# Altium schematic sheet ed3.SchDoc
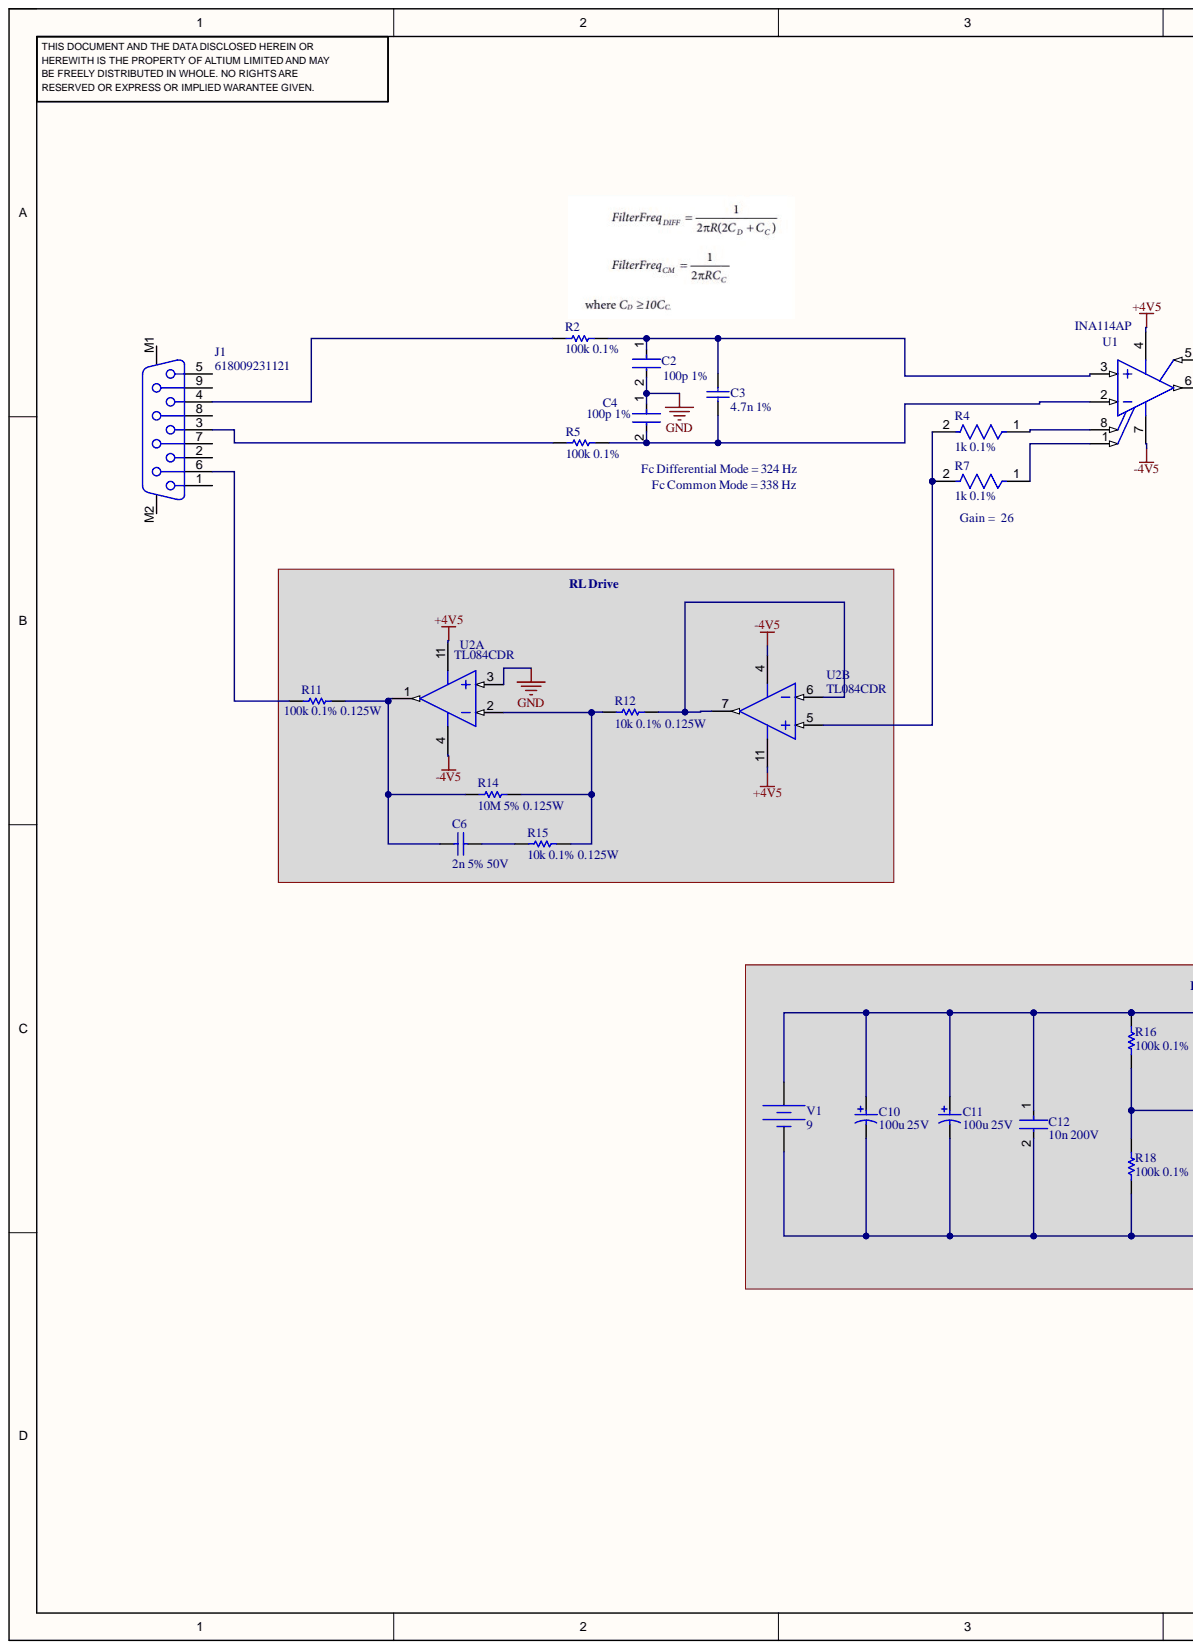
[diagram p0: ed3.SchDoc, left]
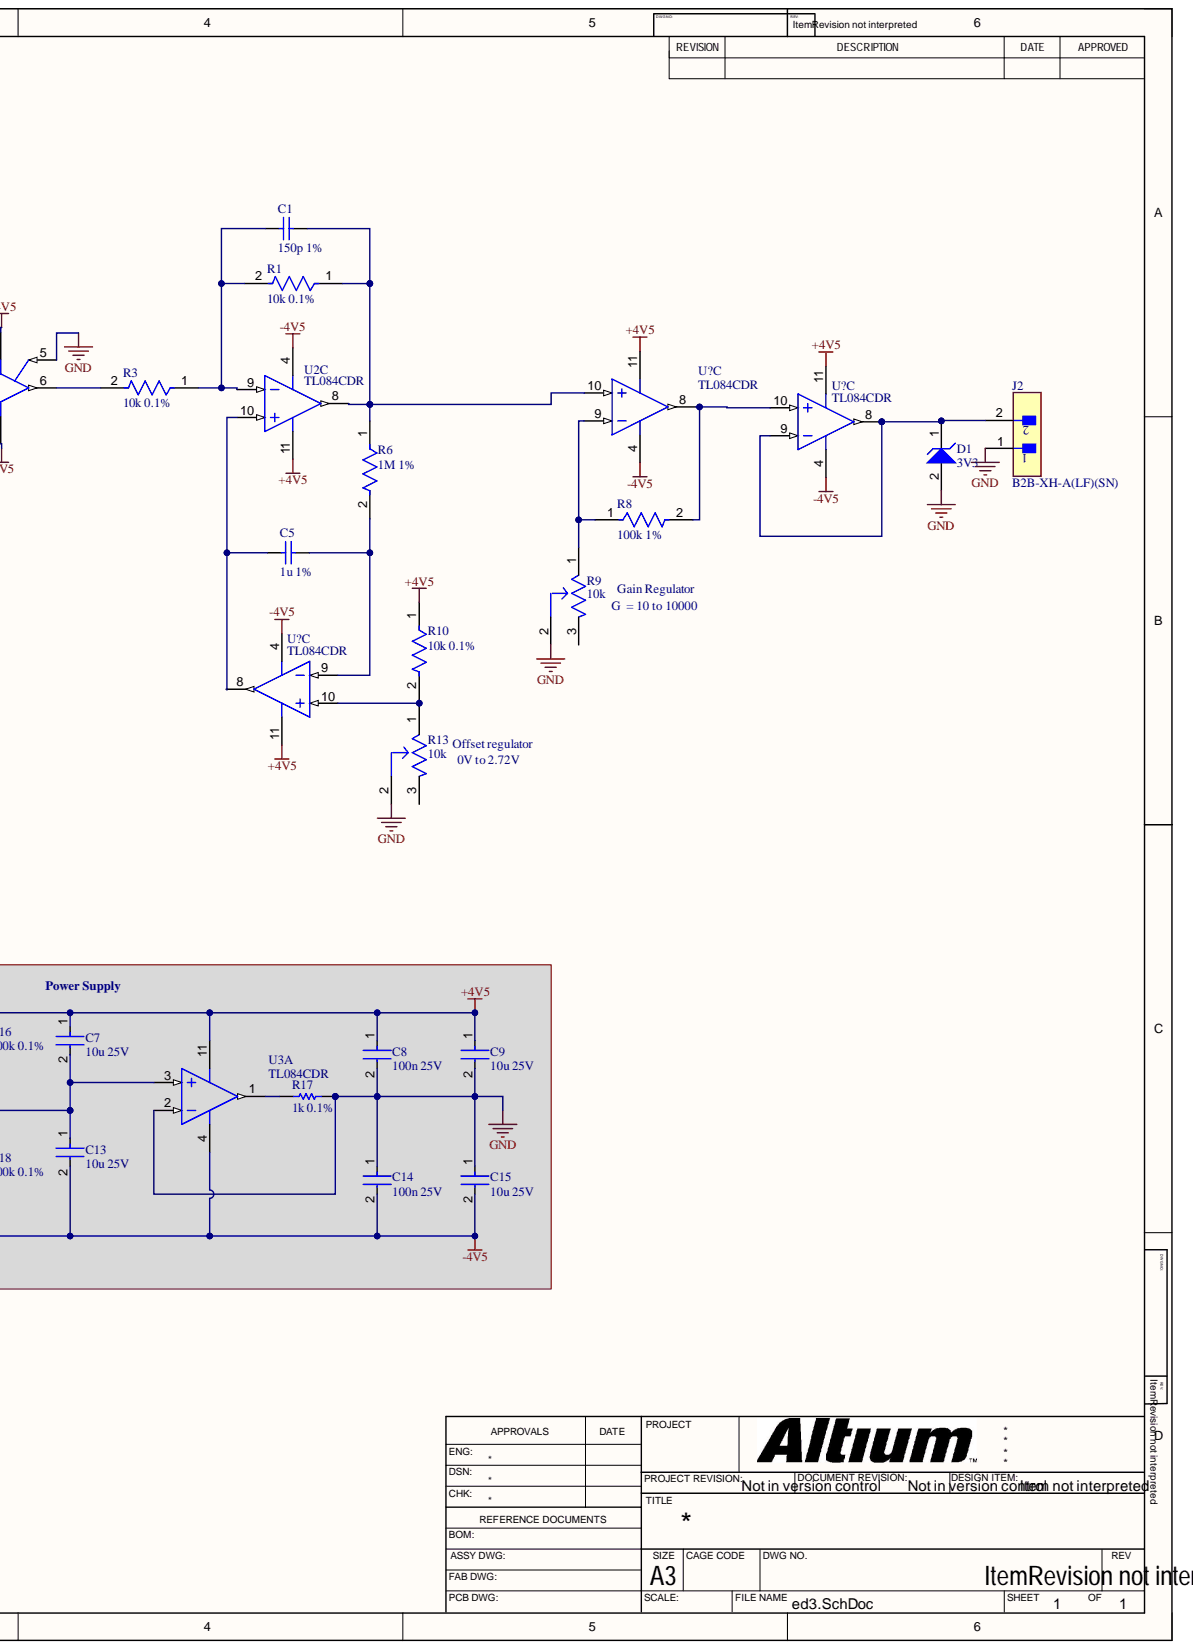
[diagram p1: ed3.SchDoc, right]

## Parts
C1  150p 1%  [CAPC2013X94X50ML20T25]
C2  100p 1%  [FP-0805-L_2_01_0_2-W_1_25-IPC_B]
C3  4.7n 1%  [CAPC2013X94X50ML20T25]
C4  100p 1%  Tolerance: 1%  [FP-0805-L_2_01_0_2-W_1_25-IPC_B]
C5  1u 1%  [CAPC2013X94X50ML20T25]
C6  2n 5% 50V  [CAPC2013X70X45NL10T25]
C7  10u 25V  Voltage: 25V  [FP-GRM21B-0_15-IPC_C]
C8  100n 25V  [FP-C0805C-DN-MFG]
C9  10u 25V  Voltage: 25V  [FP-GRM21B-0_15-IPC_C]
C10  100u 25V  [PNSC-FK-V-D8_V]
C11  100u 25V  Rated Voltage: 25V  [PNSC-FK-V-D8_V]
C12  10n 200V  Voltage: 200V  [FP-0805-L_2_01_0_2-W_1_25-IPC_A]
C13  10u 25V  Voltage: 25V  [FP-GRM21B-0_15-IPC_C]
C14  100n 25V  [FP-C0805C-DN-MFG]
C15  10u 25V  Voltage: 25V  [FP-GRM21B-0_15-IPC_C]
D1  3V3  [ONSC-SOD-123-2-425-04_V]
J1  618009231121  [618009231121]
J2  B2B-XH-A(LF)(SN)  [FP-B2B-XH-A_LF_SN-MFG]
R1  10k 0.1%  Resistance Tolerance: 0.1%  [FP-ERA6A-IPC_C]
R2  100k 0.1%  Tolerance: 0.1%  [RESC2013X60X40LL20T25_A]
R3  10k 0.1%  Resistance Tolerance: 0.1%  [FP-ERA6A-IPC_C]
R4  1k 0.1%  Resistance Tolerance: 0.1%  [FP-ERA6A-IPC_C]
R5  100k 0.1%  Tolerance: 0.1%  [RESC2013X60X40LL20T25_A]
R6  1M 1%  [FP-ERA6A-IPC_C]
R7  1k 0.1%  Resistance Tolerance: 0.1%  [FP-ERA6A-IPC_C]
R8  100k 1%  [FP-ERA6A-IPC_C]
R9  10k  [FP-3266W-MFG]
R10  10k 0.1%  Resistance Tolerance: 0.1%  [FP-ERA6A-IPC_C]
R11  100k 0.1% 0.125W  Tolerance: 0.1%  [RESC2013X60X40LL20T25_A]
R12  10k 0.1% 0.125W  Tolerance: 0.1%  [RESC2013X60X40LL20T25_A]
R13  10k  [FP-3266W-MFG]
R14  10M 5% 0.125W  [RESC2013X50X30LL20T20]
R15  10k 0.1% 0.125W  Tolerance: 0.1%  [RESC2013X60X40LL20T25_A]
R16  100k 0.1%  Tolerance: 0.1%  [RESC2013X60X40LL20T25_A]
R17  1k 0.1%  Tolerance: 0.1%  [RESC2013X60X40LL20T25_A]
R18  100k 0.1%  Tolerance: 0.1%  [RESC2013X60X40LL20T25_A]
U1  INA114AP  [P0008A]
U2  TL084CDR  [D0014A_N]  (parts A,B,C)
U3  TL084CDR  [D0014A_N]  (part A)
U?  TL084CDR  [D0014A_N]  (part C)
U?  TL084CDR  [D0014A_N]  (part C)
U?  TL084CDR  [D0014A_N]  (part C)
V1  Constant Voltage Source  (value not drawn)

## Nets
+4V5: C7.1 C8.1 C9.1 C10.1 C11.1 C12.1 R10.1 R16.2 U1.4 U2.11 U3.11 U?.11 V1.1
-4V5: C10.2 C11.2 C12.2 C13.2 C14.2 C15.2 R18.1 U1.7 U2.4 U3.4 U?.4 V1.2
GND: C2.2 C4.1 C8.2 C9.2 C14.1 C15.1 D1.2 J2.1 R9.2 R13.2 R17.1 U1.5 U2.3 U3.2
NetC1_1: C1.1 R1.1 R6.1 U2.8 U?.10
NetC1_2: C1.2 R1.2 R3.1 U2.9
NetC2_1: C2.1 C3.2 R2.1 U1.3
NetC3_1: C3.1 C4.2 R5.1 U1.2
NetC5_1: C5.1 R6.2 U?.9
NetC5_2: C5.2 U2.10
NetC6_1: C6.1 R11.1 R14.1 U2.1
NetC6_2: C6.2 R15.2
NetC7_2: C7.2 C13.1 R16.1 R18.2 U3.3
NetD1_1: D1.1 J2.2 U?.8 U?.9
NetJ1_3: J1.3 R5.2
NetJ1_4: J1.4 R2.2
NetJ1_6: J1.6 R11.2
NetR3_2: R3.2 U1.6
NetR4_1: R4.1 U1.8
NetR4_2: R4.2 R7.2 U2.5
NetR7_1: R7.1 U1.1
NetR8_1: R8.1 R9.1 U?.9
NetR8_2: R8.2 U?.8 U?.10
NetR10_2: R10.2 R13.1 U?.10
NetR12_1: R12.1 U2.6 U2.7
NetR12_2: R12.2 R14.2 R15.1 U2.2
NetR17_2: R17.2 U3.1

Not connected: J1 1 2 5 7 8 9 M1 M2; R9 3; R13 3

## Library data of the parts
C1 C3 C5 = CMP-1036-03569-3: Manufacturer: AVX Interconnect / Elco; Part Number: 08053A472FAT2A; Component Kind: Standard; Library Name: Altium Content Vault
C2 C4 = CMP-2007-04119-2: General Purpose Ceramic Capacitor, 0805, 100pF, 1%, C0G, 30ppm/°C, 100V; Capacitance: 100pF; Case Code (Imperial): 0805; Case Code (Metric): 2012; Case/Package: 0805; Component Kind: Standard; Construction: Flat; Depth: 1.25mm; Dielectric: C0G; Dielectric Material: Ceramic; Height: 0.71mm; Lead Free: Lead Free; Length: 2.01mm; Library Name: Altium Content Vault; Max Operating Temperature: 125°C; Min Operating Temperature: -55°C; Mount: Surface Mount; Number of Pins: 2; Package Quantity: 1; Packaging: Tape and Reel; Radiation Hardening: No; REACH SVHC: No SVHC; RoHS Compliant: Yes; Series: -; Termination: SMD/SMT; Thickness: 0.037inch; Voltage: 100V; Voltage Rating: 100V; Voltage Rating (DC): 100V; Width: 1.25mm
  C2: Tolerance: 1%
C6 = CMP-2007-00880-1: Manufacturer: Murata; Part Number: GRM2165C1H202JA01D; Component Kind: Standard; Library Name: Altium Content Vault
C7 C9 C13 C15 = CMP-2007-03541-2: Chip Multilayer Ceramic Capacitors for General Purpose, 0805, 10uF, X5R, 15%, 10%, 25V; Capacitance: 10uF; Case Code (Imperial): 0805; Case Code (Metric): 2012; Case/Package: 0805; Component Kind: Standard; Depth: 1.25mm; Dielectric: X5R; Height: 1.25mm; Lead Free: Lead Free; Length: 2mm; Library Name: Altium Content Vault; Material: Ceramic; Max Operating Temperature: 85°C; Min Operating Temperature: -55°C; Mount: Surface Mount; Package Quantity: 1; Packaging: Tape and Reel; Radiation Hardening: No; Termination: SMD/SMT; Thickness: 0.053inch; Tolerance: 10%; Voltage Rating: 25V; Voltage Rating (DC): 25V; Width: 0.049inch
C8 C14 = CMP-2007-00282-2: CAP CER 0.1UF 25V 20% X7R 0805; Manufacturer: KEMET; Manufacturer Part Number: C0805C104M3RACTU; Capacitance: 0.10 μF; Material: Ceramic; Temperature Coefficient: 30ppm/°C; Tolerance: 0.2; Value: 0.10 μF; Automotive: No; Category: Cap; Component Kind: Standard; ComponentLink2Description: Datasheet; ComponentLink2URL: https://content.kemet.com/datasheets/KEM_C1003_C0G_SMD.pdf; Device Class L1: Passive Components; Device Class L2: Capacitors; Device Class L3: Ceramic Capacitors; Digikey Description: CAP CER 0.1UF 25V 20% X7R 0805; Height: 0.88mm; Ipc Land Pattern Name: CAPC200125X78; Lead Free: Yes; Library Name: Altium Content Vault; Mouser Description: Multilayer Ceramic Capacitors MLCC - SMD/SMT 25volts 0.1uF X7R 20%; Octopart: https://octopart.com/c0805c104m3ractu-kemet-9138612; Package: C0805C-DN; RoHS: TRUE; Temperature: -55°C to +125°C; Temperature Characteristic: X7R; Temperature Range High: +125°C; Temperature Range Low: -55°C; Voltage Rating: 25 V
C10 C11 = CMP-2000-06206-1: Aluminum Electrolytic Capacitor, 100 uF, +/- 20%, 25 V, 2-Pin SMD (Size Code D8), -55 to 105 degC, RoHS, Tape and Reel; Manufacturer: Panasonic; Capacitance: 100µF; Impedance: 0.34O; Lifetime@Temperature: 2000Hrs@105°C; Ripple Current: 280mA; Type: Can; Case Size/Dimension: Ø6.3x7.7mm; Component Kind: Standard; Datasheet URL: http://industrial.panasonic.com/cdbs/www-data/pdf/RDE0000/ABA0000C1181.pdf; Datasheet Version: 03/2016; Library Name: Altium Content Vault; Manufacturer URL: http://www.panasonic.com/; Mounting Technology: SurfaceMount; Operating Temp Range: -55to+105°C; Operating Temp-Max: 105°C; Package Description: 2-Pin Electrolytic Aluminum Capacitor, Body 6.6 x 6.6 mm; Package Reference: FK-V-D8; Package URL: http://industrial.panasonic.com/cdbs/www-data/pdf/RDE0000/DME0000COL48.pdf; Series: FK
  C10: Rated Voltage: 25V
C12 = CMP-2007-04596-2: General Purpose Ceramic Capacitor, 0805, 10nF, 10%, X7R, 0.15, 200V; Dissipation Factor: 2.5%; Capacitance: 10nF; Case Code (Imperial): 0805; Case Code (Metric): 2012; Case/Package: 0805; Component Kind: Standard; Contact Plating: Tin; Depth: 1.25mm; Dielectric: X7R; Dielectric Material: Ceramic; Height: 0.94mm; Lead Free: Lead Free; Lead Pitch: 5.08mm; Length: 2.01mm; Library Name: Altium Content Vault; Max Operating Temperature: 125°C; Min Operating Temperature: -55°C; Mount: Surface Mount; Number of Pins: 2; Package Quantity: 1; Packaging: Tape and Reel; Radiation Hardening: No; REACH SVHC: No SVHC; RoHS Compliant: Yes; Termination: SMD/SMT; Thickness: 0.037inch; Tolerance: 10%; Voltage Rating: 200V; Voltage Rating (DC): 200V; Width: 0.049inch
D1 = CMP-1060-00363-1: Zener Voltage Regulator, 500 mW, 2-Pin SOD-123, Pb-Free, Tape and Reel; Manufacturer: On Semiconductor; PartNumber: MMSZ5226BT1G; P Max (W): 0.5; VZ Typ (V): 3.3; Component Kind: Standard; ComponentLink1Description: Manufacturer URL; ComponentLink1URL: http://www.onsemi.com/; ComponentLink2Description: Datasheet; ComponentLink2URL: http://www.onsemi.com/pub_link/Collateral/MMSZ5221BT1-D.PDF; ComponentLink3Description: Package Specification; ComponentLink3URL: http://www.onsemi.com/pub_link/Collateral/425-04.PDF; DatasheetVersion: Rev. 12; Library Name: Altium Content Vault; Mounting Technology: Surface Mount; PackageDescription: 2-Pin SOD, Body 1.6 x 2.69 mm; PackageReference: SOD-123-2-425-04; PackageVersion: Rev. G, 10/2009; Packing: Tape and Reel; RoHS: Pb-Free
J1 = CMP-1502-00200-2: NexarPartId: 18818187; Contact Resistance: 30mΩ; Current Rating: 3A; Gender: Female; Insulation Resistance: 5GΩ; Number of Contacts: 9; Operating Supply Voltage: 125V; Pitch: 2.77mm; Component Kind: Standard; Contact Material: Gold, Nickel; Contact Plating: Gold; Depth: 18.5mm; Height: 12.7mm; Housing Material: Steel; Insulation Material: PBT; Length: 30.8mm; Library Name: Altium Content Vault; Max Operating Temperature: 105°C; Min Operating Temperature: -55°C; Mount: Through Hole; Number of Pins: 9; Number of Rows: 2; Orientation: Right Angle; REACH SVHC: Unknown; RoHS: Compliant; Termination: SMD/SMT; Voltage Rating: 125V; Width: 12.5mm
J2 = CMP-2000-05888-3: CONN HEADER VERT 2POS 2.5MM; Connector Type: Male Pin; Contact Resistance: 20mR; Current Rating: 3A; Insulation Resistance: 1GR; Max Voltage Rating (AC): 250V; Number of Contacts: 2; Wire/Cable Gauge: 22AWG; Color: Natural; Component Kind: Standard; Contact Material: Brass; Contact Plating: Tin; Contact Type: Male Pin; Depth: 5.75mm; Fastening Type: Detent; Housing Color: White; Housing Material: Polyamide; Lead Free: Lead Free; Lead Pitch: 2.5mm; Length: 7.4mm; Library Name: Altium Content Vault; Max Current Rating: 3A; Max Operating Temperature: 85°C; Max Voltage Rating (DC): 250V; Min Operating Temperature: -25°C; Mount: Through Hole; Number of Positions: 2; Number of Rows: 1; Orientation: Straight; Packaging: Bulk; Pitch: 2.5mm; REACH SVHC: No SVHC; Series: XH; Termination: Solder; Voltage Rating: 250V; Voltage Rating (AC): 250V
R1 R3 R4 R6-R8 R10 = CMP-2001-00581-2: RES 1K OHM 0.1% 1/8W 0805; Max Power Dissipation: 125mW; Resistance: 1kR; Temperature Coefficient: 25ppm/°C; Voltage Rating: 100V; Case Code (Imperial): 0805; Case Code (Metric): 2012; Case/Package: 0805; Component Kind: Standard; Construction: Rectangular; Contact Plating: Tin; Depth: 1.25mm; Height: 0.5mm; Length: 2mm; Library Name: Altium Content Vault; Max Operating Temperature: 155°C; Military Standard: Not; Min Operating Temperature: -55°C; Mount: Surface Mount; Number of Pins: 2; Number of Terminations: 2; Package Quantity: 5000; Packaging: Tape and Reel; Power Rating: 125mW; Radiation Hardening: No; REACH SVHC: No SVHC; Resistor Type: Thin Film; RoHS Compliant: Yes; Series: ERA-6A; Technology: THIN FILM; Termination: SMD/SMT; Tolerance: 0.1%; Width: 1.25mm; Working Voltage: 100V
  R6 R8: Resistance Tolerance: 0.1%
R2 R5 R11 R12 R15-R18 = CMP-2001-00582-2: Metal Film Chip Resistor, High Reliability Type, 0.125 W, +/- 0.1%, -55 to 155 degC, 0805 (2012 Metric), RoHS, Tape and Reel; Brand: Panasonic; Power Rating: 125mW(1/8W); Resistance: 10kOhms; Temperature Coefficient: 25PPM/C; Voltage Rating: 100V; Case Code - in: 0805; Case Code - mm: 2012; Component Kind: Standard; Datasheet URL: https://industrial.panasonic.com/cdbs/www-data/pdf/RDM0000/AOA0000C307.pdf; Datasheet Version: 02/2016; Factory Pack Quantity: 5000; Height: 0.5mm; Length: 2mm; Library Name: Altium Content Vault; Manufacturer: Panasonic; Manufacturer URL: http://www.panasonic.com/; Mounting Technology: SurfaceMount; Operating Temperature Range: -55Cto+155C; Package / Case: 0805(2012metric); Package Description: 2-Pin Surface Mount Device, Body 2 x 1.25 mm; Package Reference: 0805; Packaging: Reel; Product: ThinFilmResistorsSMD; Product Category: ThinFilmResistors-SMD; RoHS: Details; Series: ERA-6A; Termination Style: SMD/SMT; Type: MetalFilmChipResistors; Width: 1.25mm
R9 R13 = CMP-07234-000016-1: TRIMMER 10K OHM 0.25W PC PIN TOP; Manufacturer: Bourns; Manufacturer Part Number: 3266W-1-103LF; Resistance: 10kΩ; Standoff Height: 0.43mm; Temperature Coefficient: 100ppm/°C; Tolerance: 10%; Value: 10kΩ; Automotive: No; Category: Res; Component Kind: Standard; ComponentLink2Description: Datasheet; ComponentLink2URL: https://www.bourns.com/docs/Product-Datasheets/3266.pdf; Composition: Cermet; Device Class L1: Passive Components; Device Class L2: Resistors; Device Class L3: Variable Resistors and Potentiometers; Digikey Description: TRIMMER 10K OHM 0.25W PC PIN TOP; Height: 9.02mm; Lead Free: Yes; Library Name: Altium Content Vault; Mouser Description: Trimmer Resistors - Through Hole 1/4 10Kohms 10% SQ W/Standoff Sealed; Octopart: https://octopart.com/3266w-1-103lf-bourns-81445; Package: 3266W; Power Rating: 0.25W; RoHS: TRUE; Series: 3266; Temperature: -55°C to +125°C; Temperature Range High: +125°C; Temperature Range Low: -55°C
R14 = CMP-2001-04285-1: Manufacturer: Vishay Dale; Part Number: CRCW080510M0JNEA; Component Kind: Standard; Library Name: Altium Content Vault
U1 = CMP-0992-00143-2: Precision Instrumentation Amplifier, -40 to 85 degC, 8-pin DIP (P8), Green (RoHS & no Sb/Br); Manufacturer: Texas Instruments; CMRR(Min)(dB): 106; Gain(V/V): 1 to 10000; Input Bias Current (+/-)(Max)(nA): 5; Input Offset (+/-)(Max)(uV): 125; Input Offset Drift (+/-)(Max)(uV/Degrees Celsius): 1; Noise at 1kHz(Typ)(nV/rt(Hz)): 11; Non-Linearity (+/-)(Max)(%): 0.004; Output Offset (+/-)(Max)(uV): 500/G; Output Offset Drift (+/-)(Max)(uV/Degrees Celsius): 10/G; Quiescent Current (+/-)(Typ)(mA): 2.2; SubFamily: Dual Supply; Vs(Max)(V): 36; Vs(Min)(V): 4.5; Code_JEDEC: MS-001; Component Kind: Standard; ComponentLink1Description: Manufacturer URL; ComponentLink1URL: http://www.ti.com/; ComponentLink2Description: Datasheet; ComponentLink2URL: http://www.ti.com/general/docs/lit/getliterature.tsp?genericPartNumber=INA114&fileType=pdf; ComponentLink3Description: Package Specification; ComponentLink3URL: http://www.ti.com/litv/pdf/mpdi001b; DatasheetVersion: SBOS014; Eco-Plan: Green (RoHS & no Sb/Br); Library Name: Altium Content Vault; Mounting Technology: Through Hole; Number of Channels: 1; PackageDescription: 8-Pin Plastic In-Line, Body 9.59 x 6.35 mm, Pitch 2.54 mm; PackageReference: P0008A; PackageVersion: 4040082/E, 04/2010; PartNumber: INA114AP
U2 U3 U? U? U? = CMP-0017-00129-2: JFET-Input Operational Amplifier, 7 to 36 V, 0 to 70 degC, 14-pin SOIC (D14), Green (RoHS & no Sb/Br); Manufacturer: Texas Instruments; CMRR(Min)(dB): 70; GBW(Typ)(MHz): 3; IIB(Max)(pA): 400; Iq per channel(Max)(mA): 2.8; Number of Channels: 4; Offset Drift(Typ)(uV/C): 18; Rating: Catalog; Slew Rate(Typ)(V/us): 13; Sub Family: General Purpose Amplifier, High Supply Voltage Amplifier (>=30V); Total Supply Voltage(Max)(+5V is 5, +/-5V is 10): 36; Total Supply Voltage(Min)(+5V is 5, +/-5V is 10): 7; Vio (25C)(Max)(mV): 15; Code_JEDEC: MS-012; Component Kind: Standard; ComponentLink1Description: Manufacturer URL; ComponentLink1URL: http://www.ti.com/; ComponentLink2Description: Datasheet; ComponentLink2URL: http://www.ti.com/lit/ds/symlink/tl084.pdf; ComponentLink3Description: Package Specification; ComponentLink3URL: http://www.ti.com/lit/ml/mpds177g/mpds177g.pdf; DatasheetVersion: SLOS081G; Eco-Plan: Green (RoHS & no Sb/Br); Library Name: Altium Content Vault; Mounting Technology: Surface Mount; PackageDescription: 14-Pin Plastic Small Outline, Body 8.65 x 3.90 mm, Pitch 1.27 mm; PackageReference: D0014A; PackageVersion: 4040047-5/M, 06/2011; PartNumber: TL084CDR; Vn at 1kHz(Typ)(nV/rtHz): 18
V1 = Battery: DC Magnitude: 9; SimulationGenericComponent: True; Component Kind: Standard; Description: Constant Voltage Source; Library Name: Simulation Generic Components.IntLib; Simulation: Vconst
